# Altium schematic sheet power.SchDoc(power)
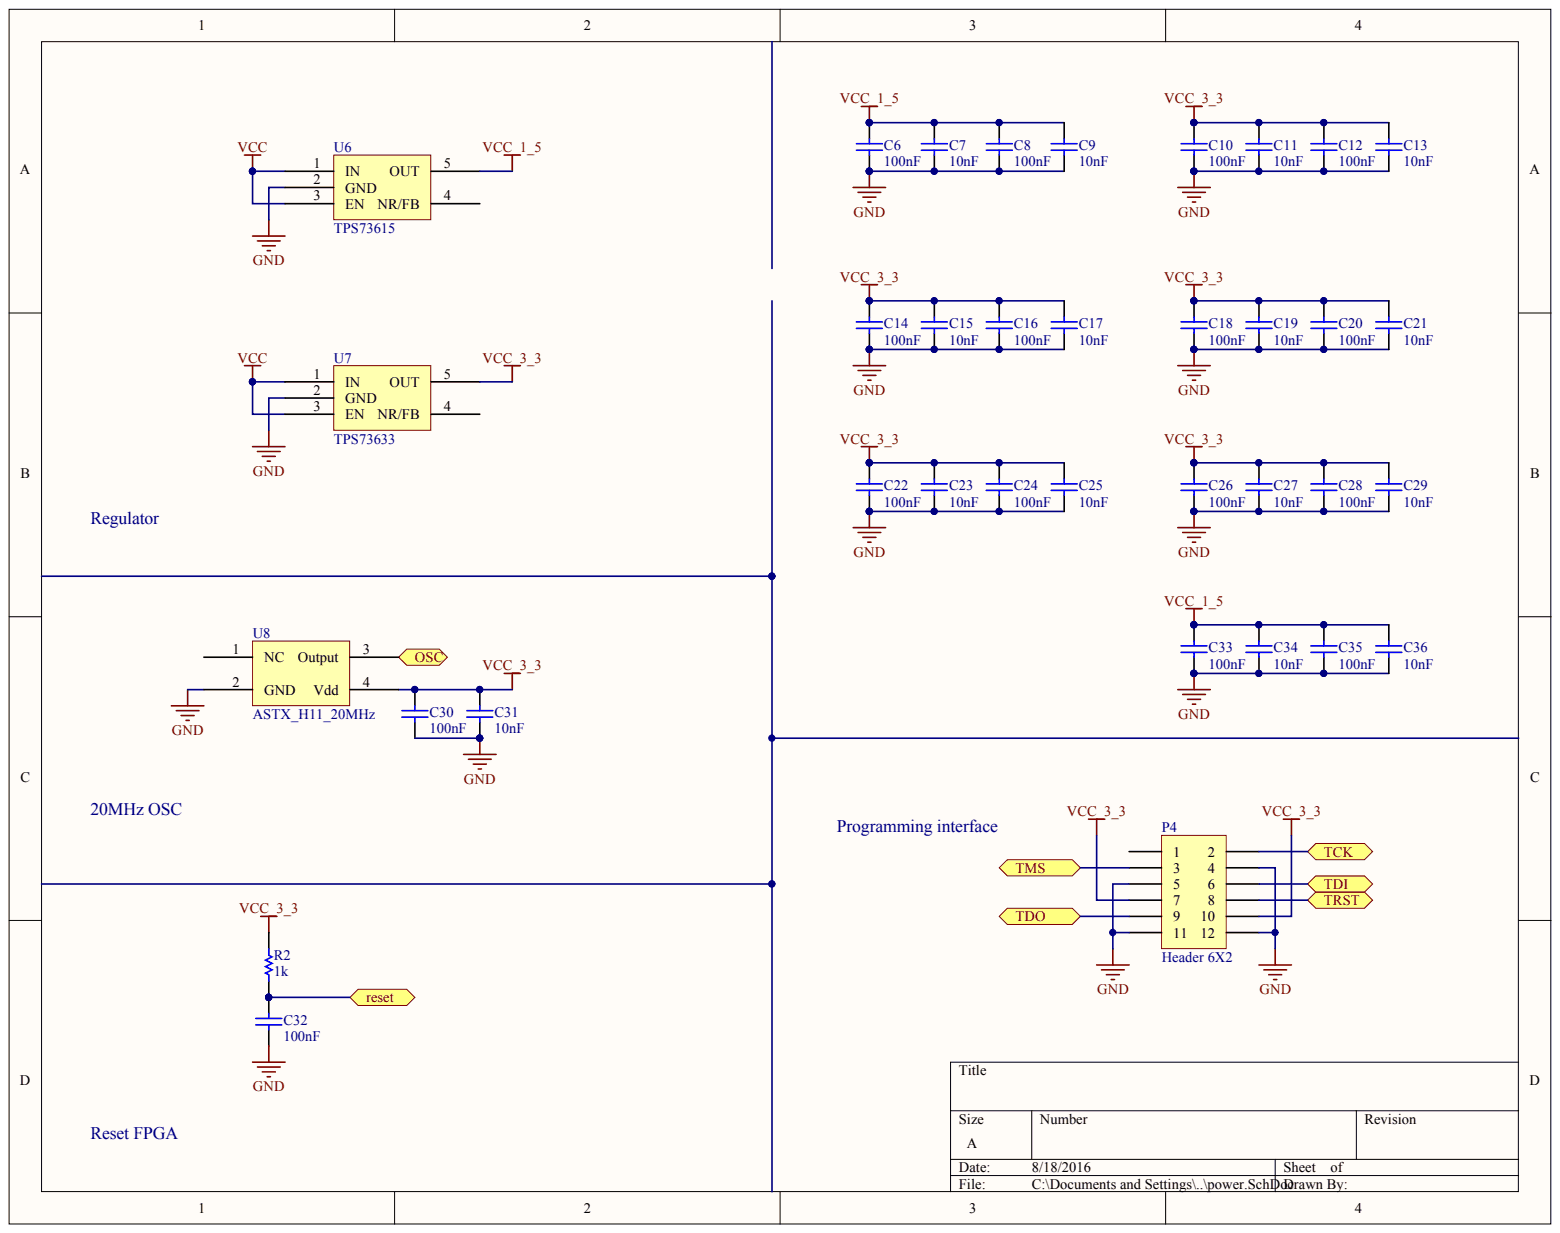
[diagram p0: power.SchDoc]

## Parts
C6  value 100nF  (hidden comment: Cap)
C7  value 10nF  (hidden comment: Cap)
C8  value 100nF  (hidden comment: Cap)
C9  value 10nF  (hidden comment: Cap)
C10  value 100nF  (hidden comment: Cap)
C11  value 10nF  (hidden comment: Cap)
C12  value 100nF  (hidden comment: Cap)
C13  value 10nF  (hidden comment: Cap)
C14  value 100nF  (hidden comment: Cap)
C15  value 10nF  (hidden comment: Cap)
C16  value 100nF  (hidden comment: Cap)
C17  value 10nF  (hidden comment: Cap)
C18  value 100nF  (hidden comment: Cap)
C19  value 10nF  (hidden comment: Cap)
C20  value 100nF  (hidden comment: Cap)
C21  value 10nF  (hidden comment: Cap)
C22  value 100nF  (hidden comment: Cap)
C23  value 10nF  (hidden comment: Cap)
C24  value 100nF  (hidden comment: Cap)
C25  value 10nF  (hidden comment: Cap)
C26  value 100nF  (hidden comment: Cap)
C27  value 10nF  (hidden comment: Cap)
C28  value 100nF  (hidden comment: Cap)
C29  value 10nF  (hidden comment: Cap)
C30  value 100nF  (hidden comment: Cap)
C31  value 10nF  (hidden comment: Cap)
C32  value 100nF  (hidden comment: Cap)
C33  value 100nF  (hidden comment: Cap)
C34  value 10nF  (hidden comment: Cap)
C35  value 100nF  (hidden comment: Cap)
C36  value 10nF  (hidden comment: Cap)
P4  Header 6X2
R2  value 1k  (hidden comment: Res)
U6  TPS73615
U7  TPS73633
U8  ASTX_H11_20MHz

## Ports
OSC, RESET, TCK, TDI, TDO, TMS, TRST

## Nets
GND: C6.1 C7.1 C8.1 C9.1 C10.1 C11.1 C12.1 C13.1 C14.1 C15.1 C16.1 C17.1 C18.1 C19.1 C20.1 C21.1 C22.1 C23.1 C24.1 C25.1 C26.1 C27.1 C28.1 C29.1 C30.1 C31.1 C32.1 C33.1 C34.1 C35.1 C36.1 P4.4 P4.5 P4.11 P4.12 U6.2(GND) U7.2(GND) U8.2(GND)
VCC: U6.1(IN) U6.3(EN) U7.1(IN) U7.3(EN)
VCC_1_5: C6.2 C7.2 C8.2 C9.2 C33.2 C34.2 C35.2 C36.2 U6.5(OUT)
VCC_3_3: C10.2 C11.2 C12.2 C13.2 C14.2 C15.2 C16.2 C17.2 C18.2 C19.2 C20.2 C21.2 C22.2 C23.2 C24.2 C25.2 C26.2 C27.2 C28.2 C29.2 C30.2 C31.2 P4.7 P4.10 R2.2 U7.5(OUT) U8.4(Vdd)
OSC: U8.3(Output) ; port OSC
TCK: P4.2 ; port TCK
TDI: P4.6 ; port TDI
TDO: P4.9 ; port TDO
TMS: P4.3 ; port TMS
TRST: P4.8 ; port TRST
NetC32_2: C32.2 R2.1 ; port RESET
N00101:
N00102:

Not connected: P4 1; U6 4(NR/FB); U7 4(NR/FB); U8 1(NC)

## Library data of the parts
C6-C36 = (no symbol name): Manufacturer: Generic Ceramic Chip Cap (TBD); Digi-Key Part Number: *; Manufacturer Part Number: *; Note: *; Rating: *
R2 = (no symbol name): Manufacturer: Generic Chip Res (TBD); Manufacturer Part Number: *; Digi-Key Part Number: *; Rating: *
P4 U6-U8 = (no symbol name)
  P4: LatestRevisionDate: 17-Jul-2002; LatestRevisionNote: Re-released for DXP Platform.; Publisher: Altium Limited
